# Altium schematic sheet Proximity-Sensor-Schematic.SchDoc(Proximity-Sensor-Schematic)
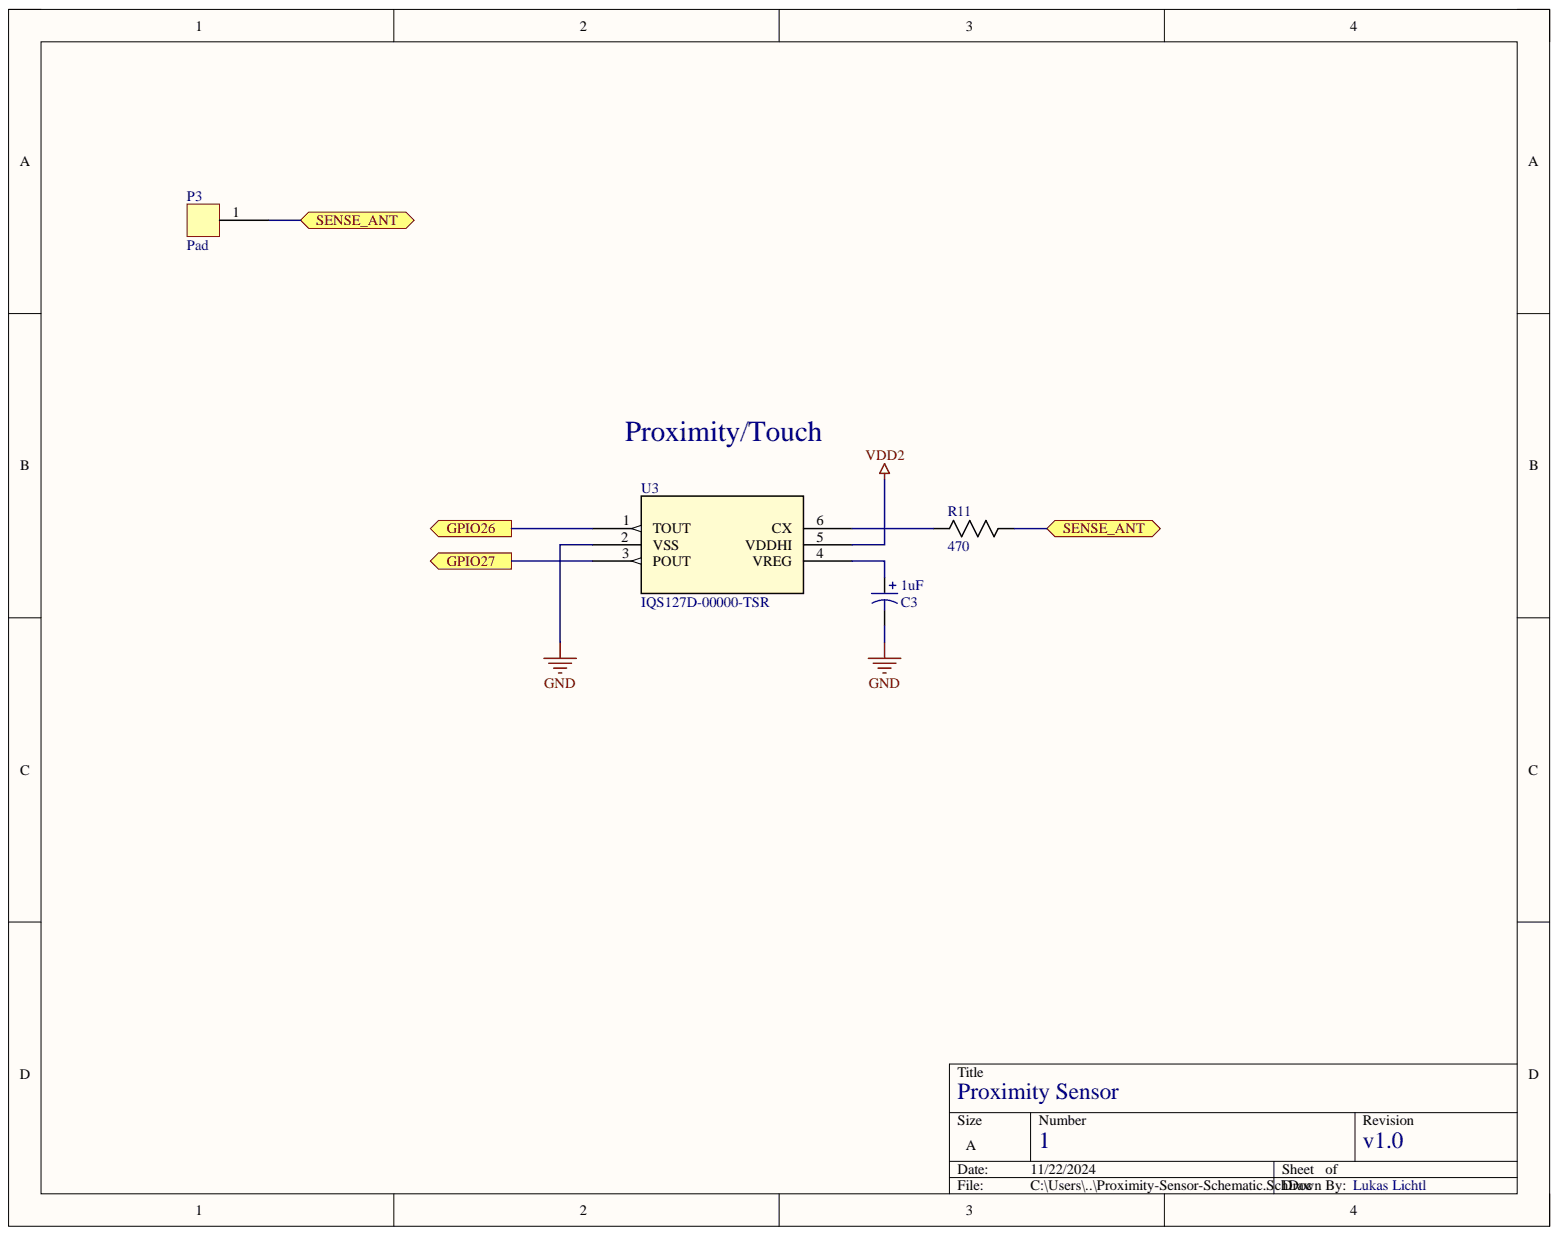
[diagram p0: Proximity-Sensor-Schematic.SchDoc]

## Parts
C3  value 1uF  [C0805]  (hidden comment: Cap Pol3)
P3  Pad  [Simple-PAD-PCB]
R11  470  [RC0603N_YAG]
U3  IQS127D-00000-TSR  [TSOT23-6_AZQ]

## Ports
GPIO26, GPIO27, SENSE_ANT

## Nets
GND: C3.2 U3.2(VSS)
VDD2: U3.5(VDDHI)
GPIO26: U3.1(TOUT) ; port GPIO26
GPIO27: U3.3(POUT) ; port GPIO27
SENSE_ANT: P3.1 R11.1 ; port SENSE_ANT
NetC3_1: C3.1 U3.4(VREG)
NetR11_2: R11.2 U3.6(CX)

## Library data of the parts
C3 = Cap Pol3: Polarized Capacitor (Surface Mount); Component Kind: Standard; LatestRevisionDate: 29-May-2009; LatestRevisionNote: IPC-7351 Footprint Added.; Library Name: Miscellaneous Devices.IntLib; PackageDescription: Chip Capacitor; PackageReference: C0805; Published: 8-Jun-2000; Simulation: CAP; Publisher: Altium Limited
R11 = RC0603FR-07470RL: No Description Available; Mfr_Name: Yageo; Component Kind: Standard; Library Name: RC0603FR-07470RL.IntLib
U3 = IQS127D-00000-TSR: No Description Available; Mfr_Name: Azoteq; Component Kind: Standard; Library Name: IQS127D-00000-TSR.IntLib; RefDes: RefDes; Type: Type
P3 = Pad: Component Kind: Standard; Library Name: Simple-PAD.SchLib
